# Altium schematic sheet Supercap_Board_1-0.SchDoc(Supercap_Board_1-0)
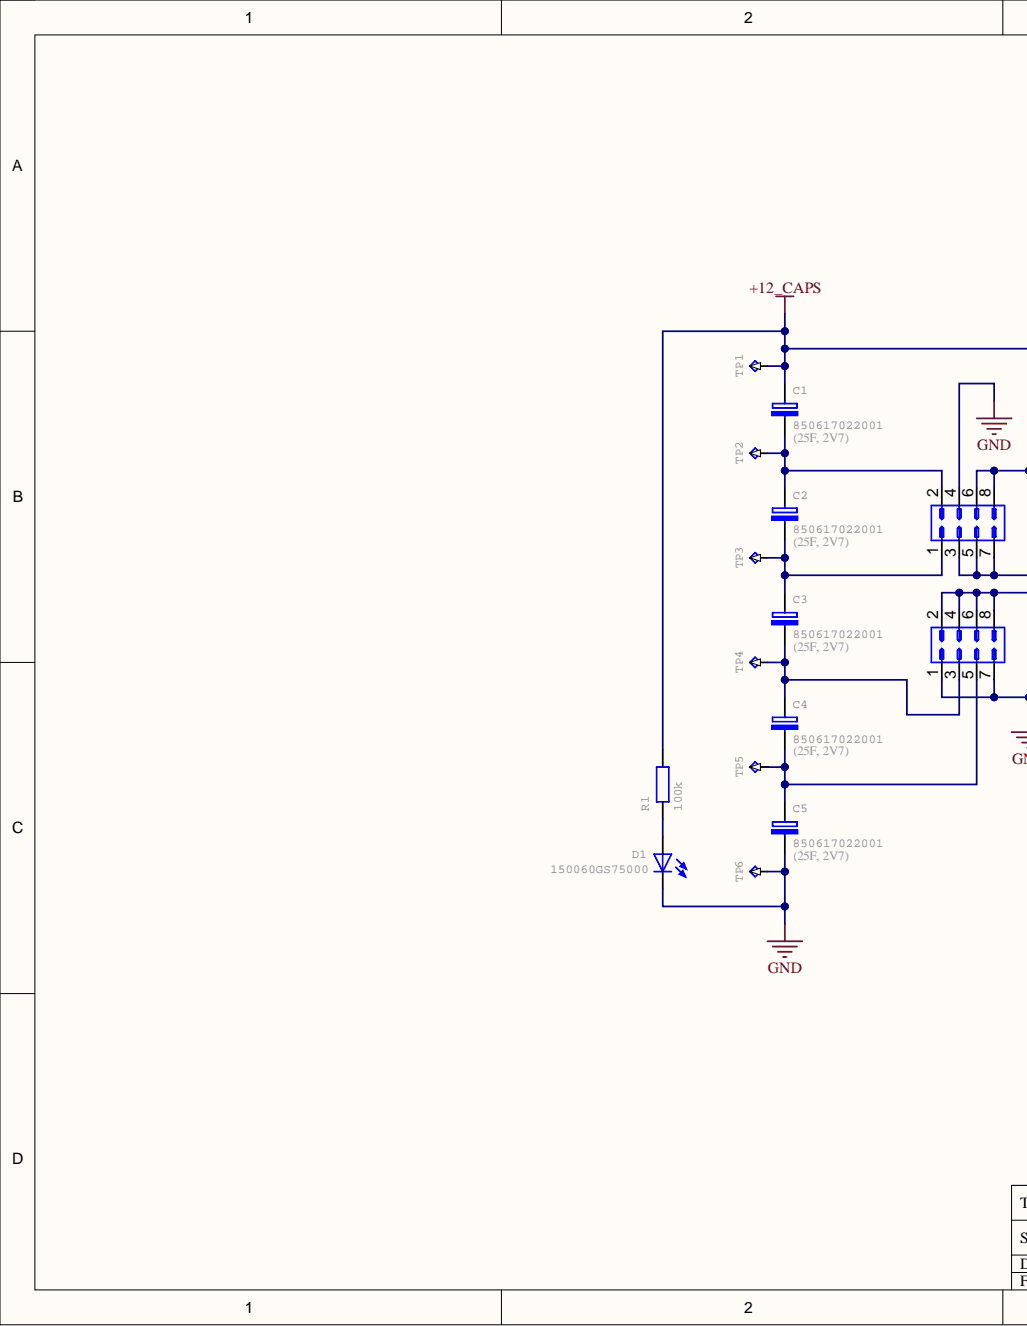
[diagram p0: Supercap_Board_1-0.SchDoc, left]
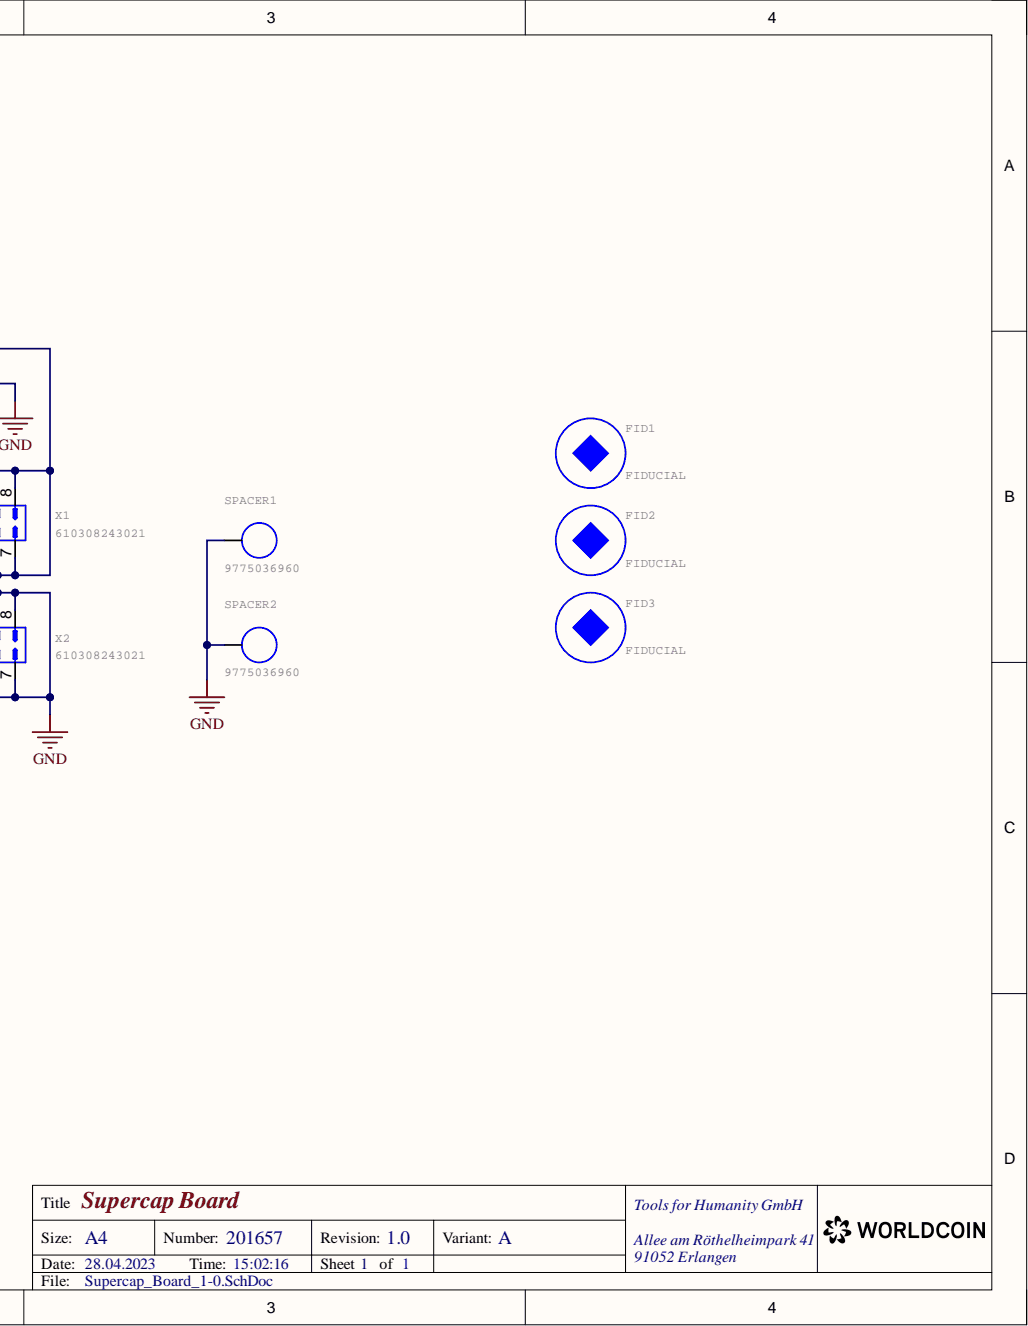
[diagram p1: Supercap_Board_1-0.SchDoc, right]

## Parts
C1  850617022001  [WCAP-STSC_16-0X25-0_WE]
C2  850617022001  [WCAP-STSC_16-0X25-0_WE]
C3  850617022001  [WCAP-STSC_16-0X25-0_WE]
C4  850617022001  [WCAP-STSC_16-0X25-0_WE]
C5  850617022001  [WCAP-STSC_16-0X25-0_WE]
D1  150060GS75000  [WL-SMCW_0603_WE]
FID1  FIDUCIAL  [FIDUCIAL]  (no BOM)
FID2  FIDUCIAL  [FIDUCIAL]  (no BOM)
FID3  FIDUCIAL  [FIDUCIAL]  (no BOM)
R1  100k  [R0603]
SPACER1  9775036960  [WA-SMSR_9775036960_WUERTH]
SPACER2  9775036960  [WA-SMSR_9775036960_WUERTH]
TP1  TESTPOINT_VIA_ROUND_0.8MM  [TESTVIA_ROUND_0-8MM]  (value not drawn)  (no BOM)
TP2  TESTPOINT_VIA_ROUND_0.8MM  [TESTVIA_ROUND_0-8MM]  (value not drawn)  (no BOM)
TP3  TESTPOINT_VIA_ROUND_0.8MM  [TESTVIA_ROUND_0-8MM]  (value not drawn)  (no BOM)
TP4  TESTPOINT_VIA_ROUND_0.8MM  [TESTVIA_ROUND_0-8MM]  (value not drawn)  (no BOM)
TP5  TESTPOINT_VIA_ROUND_0.8MM  [TESTVIA_ROUND_0-8MM]  (value not drawn)  (no BOM)
TP6  TESTPOINT_VIA_ROUND_0.8MM  [TESTVIA_ROUND_0-8MM]  (value not drawn)  (no BOM)
X1  610308243021  [610308243021_WUERTH]
X2  610308243021  [610308243021_WUERTH]

## Nets
+12_CAPS: C1.+ R1.2 TP1.TP X1.3 X1.5 X1.6 X1.7 X1.8
GND: C5.- D1.C SPACER1.1 SPACER2.1 TP6.TP X1.4 X2.1 X2.2 X2.4 X2.6 X2.7 X2.8
NetC1_-: C1.- C2.+ TP2.TP X1.2
NetC2_-: C2.- C3.+ TP3.TP X1.1
NetC3_-: C3.- C4.+ TP4.TP X2.3
NetC4_-: C4.- C5.+ TP5.TP X2.5
NetD1_A: D1.A R1.1

## Library data of the parts
C1-C5 = PAS-00001-3: 850617022001, WCAP-STSC, Supercapacitor, 25F, 2.7V; Aligni Part Number 1: 61-00498; Manufacturer 1: Wuerth; CURRENT: 0A; MAX_OP_TEMP: 65°C; MIN_OP_TEMP: -40°C; SAP Material Number 1: 200093; TOLERANCE: +30%/-10%; CHANGED: 2022-01-11; Component Kind: Standard; Component Type: Standard; CREATED: 2021-11-02; Manufacturer Part Number 1: 850617022001; ROHS: yes; STATUS: active; VOLTAGE: 2.7 V; Library Name: Worldcoin
D1 = DIS-00003-4: 150060GS75000 LED 0603 green 430 mcd WL-SMCW SMD Mono-color Chip LED Waterclear Emitting Color: Green 430 mcd (typ.) 3.2 V (typ.) 3.5 V (max.) 20 mA; Aligni Part Number 1: 61-00170; Manufacturer 1: Wuerth; CURRENT: 100mA; MAX_OP_TEMP: 85°C; MIN_OP_TEMP: -40°C; SAP Material Number 1: 200058; VOLTAGE: 5.0 V; CHANGED: 2022-01-11; Component Kind: Standard; Component Type: Standard; CREATED: 2020-11-12; Manufacturer Part Number 1: 150060GS75000; ROHS: yes; STATUS: active; Library Name: Worldcoin
FID1-FID3 = MIS-00019-5: CHANGED: 2017-11-07; Component Type: Standard (No BOM); CREATED: 2017-11-07; Description: Fiducial; Library Name: Worldcoin
R1 = PAS-00143-4: Aligni Part Number 1: 61-00651; Aligni Part Number 2: 61-00329; Aligni Part Number 3: 61-00064; Manufacturer 1: free choice; Manufacturer Part Number 1: R0603-100k-63mW-1%-400ppm-50V; CURRENT: 0A; MAX_OP_TEMP: 155°C; MIN_OP_TEMP: -55°C; POWER: 63mW; SAP Material Number 1: 200055; SAP Material Number 2: 200731; SAP Material Number 3: 200362; TEMP_COEFF: ±100 ppm/°C; TOLERANCE: ±1 %; VOLTAGE: 50.0 V; CHANGED: 2022-02-25; Component Kind: Standard; Component Type: Standard; CREATED: 2022-01-11; Description: 100k; Manufacturer 2: TE Connectivity; Manufacturer 3: Stackpole; Manufacturer Part Number 2: CPF0603F100KC1; Manufacturer Part Number 3: RMCF0603FT100K; ROHS: yes; STATUS: active; Library Name: Worldcoin
SPACER1 SPACER2 = CON-00232-1: WA-SMSR SMT Steel Spacer Reverse, through hole, L = 3.6 mm; Aligni Part Number 1: 61-00883; Manufacturer 1: Wuerth; Manufacturer Part Number 1: 9775036960R; CURRENT: 0A; MAX_OP_TEMP: 150°C; MIN_OP_TEMP: -55°C; SAP Material Number 1: 201643; CHANGED: 2023-04-21; Component Kind: Standard; CREATED: 2023-04-21; ROHS: yes; STATUS: active; Library Name: Worldcoin
TP1-TP6 = MIS-00005-5: Testpoint THT Round 1.5 mm diameter, 0.8 mm hole; CHANGED: 2019-01-16; Component Type: Standard (No BOM); CREATED: 2019-01-16; Library Name: Worldcoin
X1 X2 = CON-00016-8: WR-PHD, 2.54 mm, SMT, bottom entry socket, 2x4 pins; Aligni Part Number 1: 61-00882; Manufacturer 1: Würth; CURRENT: 3A; MAX_OP_TEMP: 125°C; MIN_OP_TEMP: -40°C; SAP Material Number 1: 201633; VOLTAGE: 250 VAC; CHANGED: 2023-04-21; Component Kind: Standard; CREATED: 2021-08-02; Manufacturer Part Number 1: 610308243021; ROHS: yes; STATUS: active; Library Name: Worldcoin
